# Altium schematic sheet CPLDTester.SchDoc(CPLDTester)
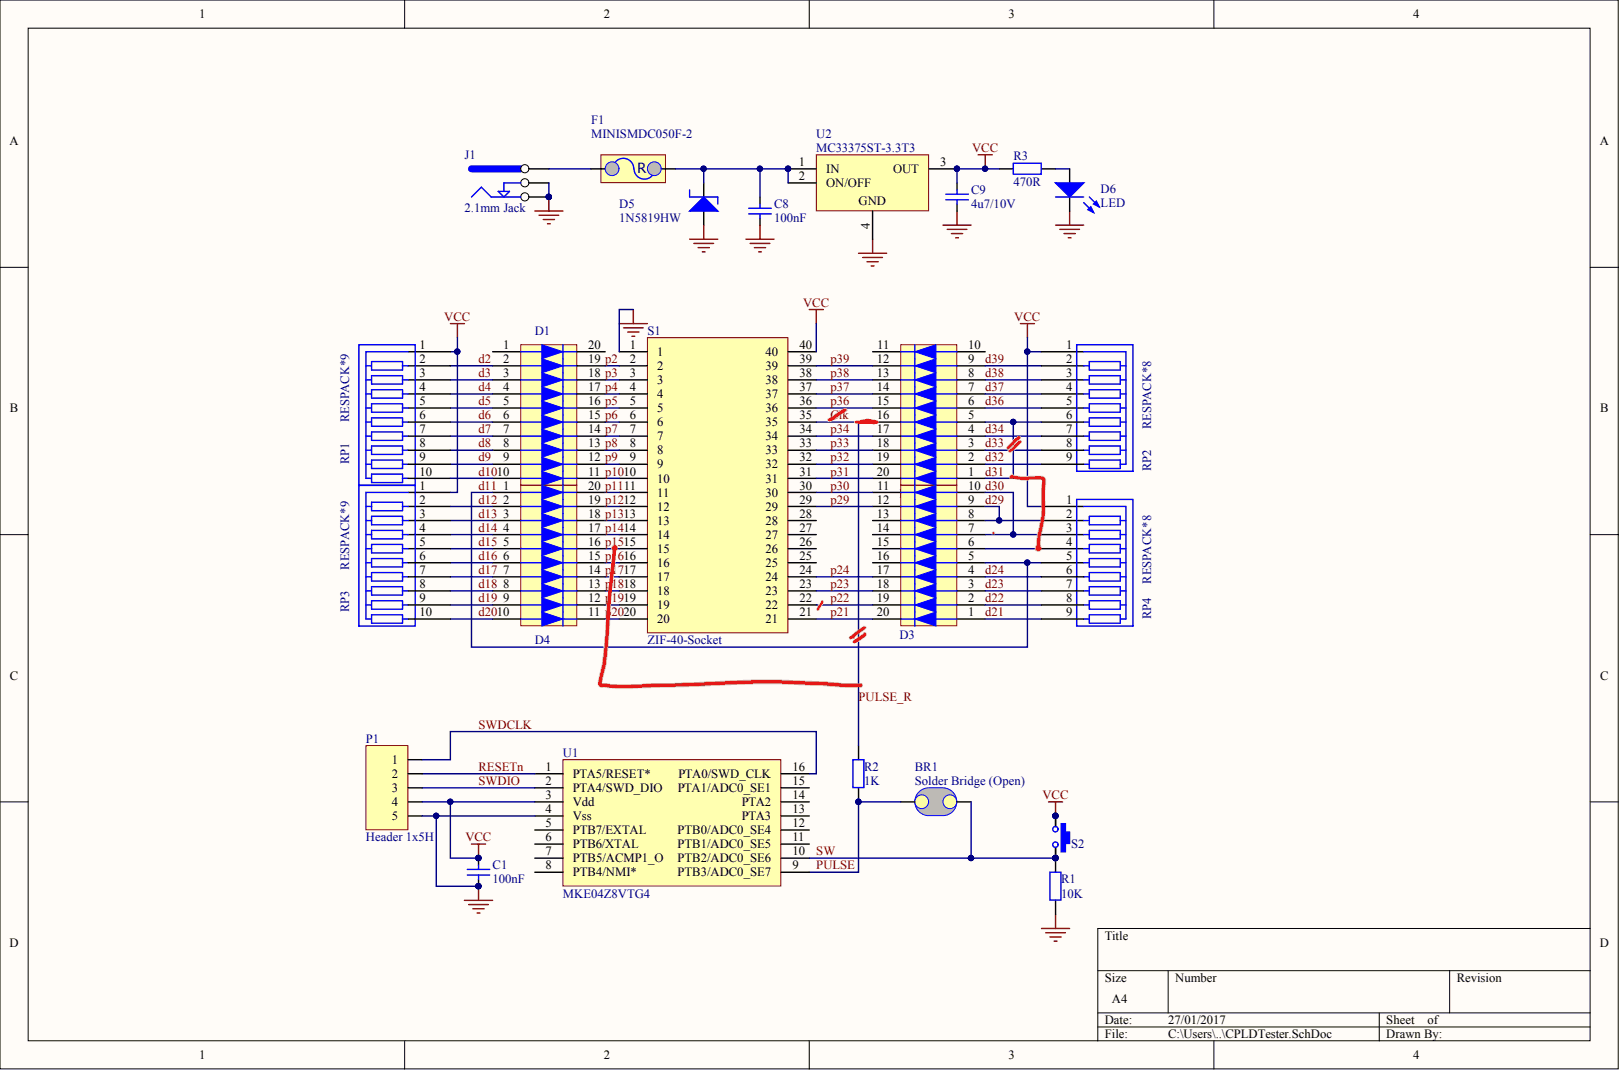
[diagram p0: CPLDTester.SchDoc]

## Parts
BR1  Solder Bridge (Open)  [SolderBridge(Open)]  (no BOM)
C1  100nF  [CCNP2012-0805]
C8  100nF  [CCNP2012-0805]
C9  4u7/10V  [CCNP2012-0805]
D1  LED Array x 10  [LED20]  (value not drawn)
D2  LED Array x 10  [LED20]  (value not drawn)
D3  LED Array x 10  [LED20]  (value not drawn)
D4  LED Array x 10  [LED20]  (value not drawn)
D5  1N5819HW  [SOD-123]
D6  LED  [LED3MM-RED]
F1  MINISMDC050F-2  [PTC4532-1812]
J1  2.1mm Jack  [2.1MMJACK]
P1  Header 1x5H  [HDR1X5H]
R1  10K  [CR2012-0805]
R2  1K  [CR2012-0805]
R3  470R  [CR2012-0805]
RP1  RESPACK*9  [SIP10]
RP2  RESPACK*8  [SIP9]
RP3  RESPACK*9  [SIP10]
RP4  RESPACK*8  [SIP9]
S1  ZIF-40-Socket  [ZIF40]
S2  SW_PB-FSM4JAH  [SW_MIN_PB-FSM4JAH]  (value not drawn)
U1  MKE04Z8VTG4  [TSSOP16]
U2  MC33375ST-3.3T3  [SOT223]

## Nets
GND: C1.1 C8.1 C9.1 D5.A D6.K J1.2 J1.3 P1.5 R1.1 S1.1 U1.4(Vss) U2.4
VCC: C1.2 C9.2 P1.4 R3.1 RP1.1 RP2.1 RP3.1 RP4.1 S1.40 S2.2 S2.2b U1.3(Vdd) U2.3(OUT)
CLK: R2.1 S1.35
D2: D1.2 RP1.2
D3: D1.3 RP1.3
D4: D1.4 RP1.4
D5: D1.5 RP1.5
D6: D1.6 RP1.6
D7: D1.7 RP1.7
D8: D1.8 RP1.8
D9: D1.9 RP1.9
D10: D1.10 RP1.10
D11: D3.5 D4.1 RP4.5
D12: D4.2 RP3.2
D13: D4.3 RP3.3
D14: D4.4 RP3.4
D15: D4.5 RP3.5
D16: D4.6 RP3.6
D17: D4.7 RP3.7
D18: D4.8 RP3.8
D19: D4.9 RP3.9
D20: D4.10 RP3.10
D21: D3.1 RP4.9
D22: D3.2 RP4.8
D23: D3.3 RP4.7
D24: D3.4 RP4.6
D29: D3.8 D3.9 RP4.2
D30: D3.7 D3.10 RP4.3
D31: D2.1 D2.5 RP2.6
D32: D2.2 RP2.9
D33: D2.3 RP2.8
D34: D2.4 RP2.7
D36: D2.6 RP2.5
D37: D2.7 RP2.4
D38: D2.8 RP2.3
D39: D2.9 RP2.2
P2: D1.19 S1.2
P3: D1.18 S1.3
P4: D1.17 S1.4
P5: D1.16 S1.5
P6: D1.15 S1.6
P7: D1.14 S1.7
P8: D1.13 S1.8
P9: D1.12 S1.9
P10: D1.11 S1.10
P11: D4.20 S1.11
P12: D4.19 S1.12
P13: D4.18 S1.13
P14: D4.17 S1.14
P15: D4.16 S1.15
P16: D4.15 S1.16
P17: D4.14 S1.17
P18: D4.13 S1.18
P19: D4.12 S1.19
P20: D4.11 S1.20
P21: D3.20 S1.21
P22: D3.19 S1.22
P23: D3.18 S1.23
P24: D3.17 S1.24
P29: D3.12 S1.29
P30: D3.11(D2) S1.30
P31: D2.20 S1.31
P32: D2.19 S1.32
P33: D2.18 S1.33
P34: D2.17 S1.34
P36: D2.15 S1.36
P37: D2.14 S1.37
P38: D2.13 S1.38
P39: D2.12 S1.39
PULSE: BR1.2 R2.2 U1.9(PTB3/ADC0_SE7)
RESETN: P1.2 U1.1(PTA5/RESET*)
SW: BR1.1 R1.2 S2.1 S2.1b U1.10(PTB2/ADC0_SE6)
SWDCLK: P1.1 U1.16(PTA0/SWD_CLK)
SWDIO: P1.3 U1.2(PTA4/SWD_DIO)
NetC8_2: C8.2 D5.K F1.2 U2.1(IN) U2.2(ON/OFF)
NetD3_6: D3.6 RP4.4
NetD6_A: D6.A R3.2
NetF1_1: F1.1 J1.1

Not connected: D1 1 20; D2 10 11 16; D3 13 14 15 16; S1 25 26 27 28; U1 5(PTB7/EXTAL) 6(PTB6/XTAL) 7(PTB5/ACMP1_O) 8(PTB4/NMI*) 11(PTB1/ADC0_SE5) 12(PTB0/ADC0_SE4) 13(PTA3) 14(PTA2) 15(PTA1/ADC0_SE1)

## Library data of the parts
BR1 = SolderBridge(Open): Library Name: MyLibrary.IntLib
C1 C8 C9 = Cap: Capacitor SMT; Manufacturer: Generic Components; Class: Passive; Component Kind: Standard; Farnell PN: 1186187; Library Name: MyLibrary.IntLib; Published: 08/06/2000; Signal Integrity: Cap; Simulation: CAP; SubClass: Capacitor; Publisher: Altium Hobart Technology Centre
  C1 C8: Value: 100nF
  C9: Value: 4u7/10V
D1-D4 = LEDX10: DIL LED x 10; Component Kind: Standard; Library Name: MySchLib.schlib
D5 = 1N5819HW: SCHOTTKY, 1A, 40V, SOD-123; Manufacturer: Generic Components; Class: Discrete; SubClass: Diode - Switching; Component Kind: Standard; Farnell PN: 2306459; Library Name: MyLibrary.IntLib; Published: 08/06/2000; Publisher: Altium Hobart Technology Centre
D6 = LED_3MM_RED: 3mm RED LED; Manufacturer: Generic Components; Class I: Optoelectronic; Class II: LED; Component Kind: Standard; Library Name: MyLibrary.IntLib; Published: 8-Jun-2000; Revision: July-2002: Re-released for DXP Platform.; Publisher: Altium Limited
F1 = MINISMDC050F-2: PolySwitch miniSMDC Series, 500 mA, 1 A, 24 VDC; Component Kind: Standard; Farnell PN: 1175839; Library Name: MyLibrary.IntLib
J1 = 2.1mmJack: 2.1 mm Power Jack; Component Kind: Standard; Library Name: MyLibrary.IntLib
P1 = Header 1x5H: Header, 5-Pin, Right Angle; Component Kind: Standard; LatestRevisionDate: 17-Jul-2002; LatestRevisionNote: Re-released for DXP Platform.; Library Name: MyLibrary.IntLib; Signal Integrity: Connector; Publisher: Altium Limited
R1-R3 = Res_CR0805: Resistor; Manufacturer: Generic Components; Class: Passive; Component Kind: Standard; Library Name: MyLibrary.IntLib; Published: 08/06/2000; Signal Integrity: Res; Simulation: RESISTOR; SubClass: Resistor; Publisher: Altium Hobart Technology Centre
  R1: Value: 10K
  R2: Value: 1K
  R3: Value: 470R
RP1 RP3 = RESPACK*9: Resistor Pack SIP x9; Component Kind: Standard; Library Name: MySchLib.schlib
RP2 RP4 = RESPACK*8: Resistor Pack SIP x8; Component Kind: Standard; Library Name: MySchLib.schlib
S2 = SW_PB-FSM4JAH: Switch miniature push-button; Manufacturer: Generic Components; Component Kind: Standard; Digikey: 1825910-3-ND; Farnell PN: 2468761; Library Name: MyLibrary.IntLib; Published: 24-Mar-1999; Revision: July-2002: Re-released for DXP Platform.; Publisher: Altium Limited
U1 = MKE04Z8VTG4: Kinetis ARM uC; Component Kind: Standard; Library Name: MySchLib.schlib
U2 = MC33375ST-3.3T3: Low Dropout 300mA Voltage Regulator with ON/OFF Control; Component Kind: Standard; ComponentLink1Description: Manufacturer Link; ComponentLink1URL: http://www.onsemi.com/site/products/taxonomy/0,4438,503,00.html; ComponentLink2Description: Datasheet; ComponentLink2URL: http://www.onsemi.com/pub/Collateral/MC33375-D.PDF; DatasheetVersion: Mar-2000; Farnell PN: 1150444; Library Name: MyLibrary.IntLib; PackageDescription: SOT-223/SC-73; 4 Leads; Body 6.7 x 7.3 mm, inc. leads (LxW max); PackageReference: 318E-04; PackageVersion: Nov-1999; Published: 15-Apr-2002; Publisher: Altium Limited
S1 = ZIF-40-Socket: Component Kind: Standard; Library Name: MyLibrary.IntLib
